# Altium schematic sheet WiFi_Portable_Sensor_Board_C0_MCU-PWR-UI_20180525.SchDoc (continued)

## Library data of the parts
C1 = CAP1338: Capacitor; Manufacturer 1: Murata Electronics North America; Manufacturer Part Number 1: GRM155R6YA105KE11D; Description_: CAP CER 1uF 35V 10% X5R SMD 0402; Dev Tools Status: Design; Owner: Sam Charles; Populated: YES; Temperature Coeffcient: X5R; Tolerance: 10%; Type: Ceramic; Category: Capacitor; Component Kind: Standard; ComponentLink1Description: SVN Datasheet; ComponentLink1URL: https://chn-vm-apps.microchip.com/mchprepo/parts/Datasheets/c02e.pdf; ComponentLink2Description: Local Datasheet; ComponentLink3Description: Open Symbol; ComponentLink4Description: Open Footprint; ComponentLink5Description: Open Footprint 2; ComponentLink6Description: Open Footprint 3; ComponentLink7Description: Open Footprint 4; Database Table Name: Capacitor; Library Name: MCHP-CDB.DbLib; MCHP-ID: CAP1338; Operating Temperature: -55C to +85C; Package: 0402; Quantity Override: 1; Revision: A; Status: MCL Design; Supplier 1: Digi-Key; Supplier Part Number 1: 490-10019-1-ND; Symbol: Capacitor NP; ComponentLink2URL: C:\ALTIUM_WORK\00001_MCHP-CDB\Datasheets\c02e.pdf; ComponentLink3URL: C:\ALTIUM_WORK\00001_MCHP-CDB\Library\LibraryRefs\Capacitor NP.SchLib; ComponentLink4URL: C:\ALTIUM_WORK\00001_MCHP-CDB\Library\Footprints\CAP_C_0402.PcbLib
C2 = CAP1461: Capacitor; Manufacturer 1: Murata Electronics North America; Manufacturer Part Number 1: GRM188R61E106MA73D; Description_: CAP CER 10uF 25V 20% X5R SMD 0603; Dev Tools Status: Production; Owner: Tim Moffat; Populated: YES; Temperature Coeffcient: X5R; Tolerance: 20%; Type: Ceramic; Category: Capacitor; Component Kind: Standard; ComponentLink1Description: SVN Datasheet; ComponentLink1URL: https://chn-vm-apps.microchip.com/mchprepo/parts/Datasheets/GRM188R61E106MA73-01.pdf; ComponentLink2Description: Local Datasheet; ComponentLink3Description: Open Symbol; ComponentLink4Description: Open Footprint; ComponentLink5Description: Open Footprint 2; ComponentLink6Description: Open Footprint 3; ComponentLink7Description: Open Footprint 4; Database Table Name: Capacitor; Library Name: MCHP-CDB.DbLib; MCHP-ID: CAP1461; Operating Temperature: -55C to 85C; Quantity Override: 1; Revision: 1; Status: MCL Design; Supplier 1: Digi-Key; Supplier Part Number 1: 490-7202-1-ND; Symbol: Capacitor NP; ComponentLink2URL: C:\ALTIUM_WORK\00001_MCHP-CDB\Datasheets\GRM188R61E106MA73-01.pdf; ComponentLink3URL: C:\ALTIUM_WORK\00001_MCHP-CDB\Library\LibraryRefs\Capacitor NP.SchLib; ComponentLink4URL: C:\ALTIUM_WORK\00001_MCHP-CDB\Library\Footprints\CAP_C_0603.PcbLib
C3 = CAP0638: Capacitor; Manufacturer 1: Taiyo Yuden; Manufacturer Part Number 1: LMK107BJ105KA-T; Description_: CAP CER 1uF 50V 10% X5R SMD 0603; Dev Tools Status: Production; Populated: Yes; Temperature Coeffcient: X5R; Tolerance: 10%; Type: Ceramic; Category: Capacitor; Component Kind: Standard; ComponentLink1Description: SVN Datasheet; ComponentLink1URL: https://chn-vm-apps.microchip.com/mchprepo/parts/Datasheets/mlcc01_e.pdf; ComponentLink2Description: Local Datasheet; ComponentLink3Description: Open Symbol; ComponentLink4Description: Open Footprint; ComponentLink5Description: Open Footprint 2; ComponentLink6Description: Open Footprint 3; ComponentLink7Description: Open Footprint 4; Database Table Name: Capacitor; Library Name: MCHP-CDB.DbLib; MCHP-ID: CAP0638; Operating Temperature: -55C to +85C; Quantity Override: 1; Revision: 1; Status: MCL Design; Supplier 1: Digi-Key; Supplier Part Number 1: 587-2400-1-ND; Symbol: Capacitor NP; ComponentLink2URL: C:\ALTIUM_WORK\00001_MCHP-CDB\Datasheets\mlcc01_e.pdf; ComponentLink3URL: C:\ALTIUM_WORK\00001_MCHP-CDB\Library\LibraryRefs\Capacitor NP.SchLib; ComponentLink4URL: C:\ALTIUM_WORK\00001_MCHP-CDB\Library\Footprints\CAP_C_0603.PcbLib
C4 C8-C10 C12 C31 = CAP0279: Capacitor; Manufacturer 1: KEMET; Manufacturer Part Number 1: C0402C104K8PACTU; Description_: CAP CER 0.1uF 10V 10% X5R SMD 0402; Dev Tools Status: Production; Populated: Yes; Temperature Coeffcient: X5R; Tolerance: 10%; Type: Ceramic; Category: Capacitor; Component Kind: Standard; ComponentLink1Description: SVN Datasheet; ComponentLink1URL: https://chn-vm-apps.microchip.com/mchprepo/parts/Datasheets/KEM_C1002_X7R_SMD.pdf; ComponentLink2Description: Local Datasheet; ComponentLink3Description: Open Symbol; ComponentLink4Description: Open Footprint; ComponentLink5Description: Open Footprint 2; ComponentLink6Description: Open Footprint 3; ComponentLink7Description: Open Footprint 4; Database Table Name: Capacitor; Library Name: MCHP-CDB.DbLib; Manufacturer 2: Murata; Manufacturer 3: KEMET; Manufacturer Part Number 2: GRM155R61A104KA01D; Manufacturer Part Number 3: C0402C104K8PAC; MCHP-ID: CAP0279; Operating Temperature: -55C to +85C; Quantity Override: 1; Revision: 1; Status: MCL Design; Supplier 1: Digi-Key; Supplier 2: Digi-Key; Supplier 3: KEMET; Supplier Part Number 1: 399-3027-1-ND; Supplier Part Number 2: 490-1318-2-ND; Supplier Part Number 3: C0402C104K8PAC; Symbol: Capacitor NP; ComponentLink2URL: C:\ALTIUM_WORK\00001_MCHP-CDB\Datasheets\KEM_C1002_X7R_SMD.pdf; ComponentLink3URL: C:\ALTIUM_WORK\00001_MCHP-CDB\Library\LibraryRefs\Capacitor NP.SchLib; ComponentLink4URL: C:\ALTIUM_WORK\00001_MCHP-CDB\Library\Footprints\CAP_C_0402.PcbLib
C5 C13 = CAP0616: Capacitor; Manufacturer 1: Murata; Manufacturer Part Number 1: GRM155R60J105KE19D; Description_: CAP CER 1uF 6.3V 10% X5R SMD 0402; Dev Tools Status: Production; Populated: Yes; Temperature Coeffcient: X5R; Tolerance: 10%; Type: Ceramic; Category: Capacitor; Component Kind: Standard; ComponentLink1Description: SVN Datasheet; ComponentLink1URL: https://chn-vm-apps.microchip.com/mchprepo/parts/Datasheets/L0005E.pdf; ComponentLink2Description: Local Datasheet; ComponentLink3Description: Open Symbol; ComponentLink4Description: Open Footprint; ComponentLink5Description: Open Footprint 2; ComponentLink6Description: Open Footprint 3; ComponentLink7Description: Open Footprint 4; Database Table Name: Capacitor; Library Name: MCHP-CDB.DbLib; MCHP-ID: CAP0616; Operating Temperature: -55C to +85C; Quantity Override: 1; Revision: 1; Status: MCL Design; Supplier 1: Digi-Key; Supplier Part Number 1: 490-1320-1-ND; Symbol: Capacitor NP; ComponentLink2URL: C:\ALTIUM_WORK\00001_MCHP-CDB\Datasheets\L0005E.pdf; ComponentLink3URL: C:\ALTIUM_WORK\00001_MCHP-CDB\Library\LibraryRefs\Capacitor NP.SchLib; ComponentLink4URL: C:\ALTIUM_WORK\00001_MCHP-CDB\Library\Footprints\CAP_C_0402.PcbLib
C6 C7 C11 = CAP1207: Capacitor; Manufacturer 1: Murata; Manufacturer Part Number 1: GRM155R60J475ME47D; Description_: CAP CER 4.7uF 6.3V 20% X5R SMD 0402; Dev Tools Status: Production; Owner: Tad Ahlvers; Populated: YES; Temperature Coeffcient: X5R; Tolerance: 20%; Type: Ceramic; Category: Capacitor; Component Kind: Standard; ComponentLink1Description: SVN Datasheet; ComponentLink1URL: https://chn-vm-apps.microchip.com/mchprepo/parts/Datasheets/c02e.pdf; ComponentLink2Description: Local Datasheet; ComponentLink3Description: Open Symbol; ComponentLink4Description: Open Footprint; ComponentLink5Description: Open Footprint 2; ComponentLink6Description: Open Footprint 3; ComponentLink7Description: Open Footprint 4; Database Table Name: Capacitor; Library Name: MCHP-CDB.DbLib; Manufacturer 2: TDK Corporation; Manufacturer Part Number 2: C1005X5R0J475M050BC; MCHP-ID: CAP1207; Operating Temperature: -55C to +85C; Quantity Override: 1; Revision: 1; Status: MCL Design; Supplier 1: Digi-Key; Supplier 2: Digi-Key; Supplier Part Number 1: 490-5915-2-ND; Supplier Part Number 2: 445-7395-2-ND; Symbol: Capacitor NP; ComponentLink2URL: C:\ALTIUM_WORK\00001_MCHP-CDB\Datasheets\c02e.pdf; ComponentLink3URL: C:\ALTIUM_WORK\00001_MCHP-CDB\Library\LibraryRefs\Capacitor NP.SchLib; ComponentLink4URL: C:\ALTIUM_WORK\00001_MCHP-CDB\Library\Footprints\CAP_C_0402.PcbLib
C15 C16 = CAP1712: Capacitor; Manufacturer 1: Murata Electronics North America; Manufacturer Part Number 1: GRM1555C1H6R8BA01D; Description_: CAP CER 6.8pF 50V 0.1pF NP0 SMD 0402; Dev Tools Status: Design; Owner: Gabor Bihari; Populated: YES; Temperature Coeffcient: NP0; Tolerance: 0.1pF; Type: Ceramic; Category: Capacitor; Component Kind: Standard; ComponentLink1Description: SVN Datasheet; ComponentLink1URL: https://chn-vm-apps.microchip.com/mchprepo/parts/Datasheets/c02e.pdf; ComponentLink2Description: Local Datasheet; ComponentLink3Description: Open Symbol; ComponentLink4Description: Open Footprint; ComponentLink5Description: Open Footprint 2; ComponentLink6Description: Open Footprint 3; ComponentLink7Description: Open Footprint 4; Database Table Name: Capacitor; Library Name: MCHP-CDB.DbLib; MCHP-ID: CAP1712; Operating Temperature: -55°C to 125°C; Quantity Override: 1; Revision: A; Status: MCL Design; Supplier 1: Digi-Key; Supplier Part Number 1: 490-6252-1-ND; Symbol: Capacitor NP; ComponentLink2URL: C:\ALTIUM_WORK\00001_MCHP-CDB\Datasheets\c02e.pdf; ComponentLink3URL: C:\ALTIUM_WORK\00001_MCHP-CDB\Library\LibraryRefs\Capacitor NP.SchLib; ComponentLink4URL: C:\ALTIUM_WORK\00001_MCHP-CDB\Library\Footprints\CAP_C_0402.PcbLib
C30 = CAP0638: Capacitor; Manufacturer 1: Taiyo Yuden; Manufacturer Part Number 1: LMK107BJ105KA-T; Description_: CAP CER 1uF 50V 10% X5R SMD 0603; Dev Tools Status: Production; Populated: Yes; Temperature Coeffcient: X5R; Tolerance: 10%; Type: Ceramic; Voltage: 50V; Category: Capacitor; Component Kind: Standard; ComponentLink1Description: SVN Datasheet; ComponentLink1URL: https://chn-vm-apps.microchip.com/mchprepo/parts/Datasheets/mlcc01_e.pdf; ComponentLink2Description: Local Datasheet; ComponentLink3Description: Open Symbol; ComponentLink4Description: Open Footprint; ComponentLink5Description: Open Footprint 2; ComponentLink6Description: Open Footprint 3; ComponentLink7Description: Open Footprint 4; Database Table Name: Capacitor; Library Name: MCHP-CDB.DbLib; MCHP-ID: CAP0638; Operating Temperature: -55C to +85C; Package: 0603; Quantity Override: 1; Revision: 1; Status: MCL Design; Supplier 1: Digi-Key; Supplier Part Number 1: 587-2400-1-ND; Symbol: Capacitor NP; ComponentLink2URL: C:\ALTIUM_WORK\00001_MCHP-CDB\Datasheets\mlcc01_e.pdf; ComponentLink3URL: C:\ALTIUM_WORK\00001_MCHP-CDB\Library\LibraryRefs\Capacitor NP.SchLib; ComponentLink4URL: C:\ALTIUM_WORK\00001_MCHP-CDB\Library\Footprints\CAP_C_0603.PcbLib
C201 = CAP0279: Capacitor; Manufacturer 1: KEMET; Manufacturer Part Number 1: C0402C104K8PACTU; Description_: CAP CER 100nF 10V 10% X5R SMD 0402; Dev Tools Status: Production; Populated: Yes; Temperature Coeffcient: X5R; Tolerance: 10%; Type: Ceramic; Category: Capacitor; Component Kind: Standard; ComponentLink1Description: SVN Datasheet; ComponentLink1URL: https://chn-vm-apps.microchip.com/mchprepo/parts/Datasheets/KEM_C1002_X7R_SMD.pdf; ComponentLink2Description: Local Datasheet; ComponentLink3Description: Open Symbol; ComponentLink4Description: Open Footprint; ComponentLink5Description: Open Footprint 2; ComponentLink6Description: Open Footprint 3; ComponentLink7Description: Open Footprint 4; Database Table Name: Capacitor; Library Name: MCHP-CDB.DbLib; Manufacturer 2: Murata; Manufacturer 3: KEMET; Manufacturer Part Number 2: GRM155R61A104KA01D; Manufacturer Part Number 3: C0402C104K8PAC; MCHP-ID: CAP0279; Operating Temperature: -55C to +85C; Quantity Override: 1; Revision: 1; Status: MCL Design; Supplier 1: Digi-Key; Supplier 2: Digi-Key; Supplier 3: KEMET; Supplier Part Number 1: 399-3027-1-ND; Supplier Part Number 2: 490-1318-2-ND; Supplier Part Number 3: C0402C104K8PAC; Symbol: Capacitor NP; ComponentLink2URL: C:\ALTIUM_WORK\00001_MCHP-CDB\Datasheets\KEM_C1002_X7R_SMD.pdf; ComponentLink3URL: C:\ALTIUM_WORK\00001_MCHP-CDB\Library\LibraryRefs\Capacitor NP.SchLib; ComponentLink4URL: C:\ALTIUM_WORK\00001_MCHP-CDB\Library\Footprints\CAP_C_0402.PcbLib
C202 = CAP0616: Capacitor; Manufacturer 1: Murata; Manufacturer Part Number 1: GRM155R60J105KE19D; Description_: CAP CER 100nF 16V 10% X5R SMD 0402; Dev Tools Status: Production; Populated: Yes; Temperature Coeffcient: X5R; Tolerance: 10%; Type: Ceramic; Category: Capacitor; Component Kind: Standard; ComponentLink1Description: SVN Datasheet; ComponentLink1URL: https://chn-vm-apps.microchip.com/mchprepo/parts/Datasheets/L0005E.pdf; ComponentLink2Description: Local Datasheet; ComponentLink3Description: Open Symbol; ComponentLink4Description: Open Footprint; ComponentLink5Description: Open Footprint 2; ComponentLink6Description: Open Footprint 3; ComponentLink7Description: Open Footprint 4; Database Table Name: Capacitor; Library Name: MCHP-CDB.DbLib; MCHP-ID: CAP0616; Operating Temperature: -55C to +85C; Quantity Override: 1; Revision: 1; Status: MCL Design; Supplier 1: Digi-Key; Supplier Part Number 1: 490-1320-1-ND; Symbol: Capacitor NP; ComponentLink2URL: C:\ALTIUM_WORK\00001_MCHP-CDB\Datasheets\L0005E.pdf; ComponentLink3URL: C:\ALTIUM_WORK\00001_MCHP-CDB\Library\LibraryRefs\Capacitor NP.SchLib; ComponentLink4URL: C:\ALTIUM_WORK\00001_MCHP-CDB\Library\Footprints\CAP_C_0402.PcbLib
D1-D4 = DIODE1346: Description_: DIODE TVS BIDIR SPE0572 5V SMD SOD-723; Dev Tools Status: Design; Owner: Gabor Bihari; Populated: YES; Reverse Voltage: 5V; Category: Diode; Component Kind: Standard; ComponentLink1Description: SVN Datasheet; ComponentLink1URL: https://chn-vm-apps.microchip.com/mchprepo/parts/Datasheets/SPE0572.pdf; ComponentLink2Description: Local Datasheet; ComponentLink3Description: Open Symbol; ComponentLink4Description: Open Footprint; ComponentLink5Description: Open Footprint 2; ComponentLink6Description: Open Footprint 3; ComponentLink7Description: Open Footprint 4; Database Table Name: Diode; Library Name: MCHP-CDB.DbLib; MCHP-ID: DIODE1346; Operating Temperature: -55°C to 125°C; Package: SOD-723; Quantity Override: 1; Revision: A; Status: MCL Design; Symbol: Diode TVS Bidirectional; Type: TVS; ComponentLink2URL: C:\ALTIUM_WORK\00001_MCHP-CDB\Datasheets\SPE0572.pdf; ComponentLink3URL: C:\ALTIUM_WORK\00001_MCHP-CDB\Library\LibraryRefs\Diode TVS Bidirectional.SchLib; ComponentLink4URL: C:\ALTIUM_WORK\00001_MCHP-CDB\Library\Footprints\SOD-723.PcbLib
D5 = DIODE0096: DIO RECT 1N4148 855mV 300mA 75V SOD-323; Manufacturer 1: Diodes Incorporated; Manufacturer Part Number 1: 1N4148WS-7-F; Dev Tools Status: Production; Populated: Yes; Power: 200mW; Reverse Current: 50uA; Type: Rectifier Single; Category: Diode; Component Kind: Standard; ComponentLink1Description: SVN Datasheet; ComponentLink1URL: https://chn-vm-apps.microchip.com/mchprepo/parts/Datasheets/ds30097.pdf; ComponentLink2Description: Local Datasheet; ComponentLink3Description: Open Symbol; ComponentLink4Description: Open Footprint; ComponentLink5Description: Open Footprint 2; ComponentLink6Description: Open Footprint 3; ComponentLink7Description: Open Footprint 4; Database Table Name: Diode; Description_: DIO RECT 1N4148 855mV 300mA 75V SOD-323; Forward Current: 300mA; Library Name: MCHP-CDB.DbLib; Manufacturer 2: Diodes Incorporated; Manufacturer Part Number 2: 1N4148WS-7; MCHP-ID: DIODE0096; Operating Temperature: -65C to +150C; Package: SOD-323; Quantity Override: 1; Reverse Voltage: 75V; Revision: 1; Status: MCL Design; Supplier 1: Digi-Key; Supplier 2: Digi-Key; Supplier Part Number 1: 1N4148WS-FDICT-ND; Supplier Part Number 2: 1N4148WSDITR-ND; Symbol: Diode Single; ComponentLink2URL: C:\ALTIUM_WORK\00001_MCHP-CDB\Datasheets\ds30097.pdf; ComponentLink3URL: C:\ALTIUM_WORK\00001_MCHP-CDB\Library\LibraryRefs\Diode Single.SchLib; ComponentLink4URL: C:\ALTIUM_WORK\00001_MCHP-CDB\Library\Footprints\SOD-323.PcbLib
FB1 = IND1170: Manufacturer 1: TDK Corporation; Manufacturer Part Number 1: BLM18KG471SN1D; DCR: 150 mOhm Max; Description_: FERRITE CHIP 470 OHM 1A 0603; Dev Tools Status: Design; Owner: Jose Garcia; Populated: YES; Test Frequency: 100MHz; Type: Ferrite Bead; Category: Inductor; Component Kind: Standard; ComponentLink1Description: SVN Datasheet; ComponentLink1URL: https://chn-vm-apps.microchip.com/mchprepo/parts/Datasheets/beads_commercial_power_mpz_en; ComponentLink2Description: Local Datasheet; ComponentLink3Description: Open Symbol; ComponentLink4Description: Open Footprint; ComponentLink5Description: Open Footprint 2; ComponentLink6Description: Open Footprint 3; ComponentLink7Description: Open Footprint 4; Database Table Name: Inductor; Description: Inductor; Library Name: MCHP-CDB.DbLib; MCHP-ID: IND1170; Operating Temperature: –55 to +125; Quantity Override: 1; Revision: A; Status: MCL Design; Supplier 1: Digi-Key; Supplier Part Number 1: 445-5218-1-ND; Symbol: Inductor; ComponentLink2URL: C:\ALTIUM_WORK\00001_MCHP-CDB\Datasheets\beads_commercial_power_mpz_en; ComponentLink3URL: C:\ALTIUM_WORK\00001_MCHP-CDB\Library\LibraryRefs\Inductor.SchLib; ComponentLink4URL: C:\ALTIUM_WORK\00001_MCHP-CDB\Library\Footprints\IND_C_0603.PcbLib
FB2 FB3 = IND1170: Manufacturer 1: TDK Corporation; DCR: 150 mOhm Max; Description_: FERRITE CHIP 470 OHM 1A 0603; Dev Tools Status: Design; Owner: Jose Garcia; Populated: YES; Test Frequency: 100MHz; Type: Ferrite Bead; Category: Inductor; Component Kind: Standard; ComponentLink1Description: SVN Datasheet; ComponentLink1URL: https://chn-vm-apps.microchip.com/mchprepo/parts/Datasheets/beads_commercial_power_mpz_en; ComponentLink2Description: Local Datasheet; ComponentLink3Description: Open Symbol; ComponentLink4Description: Open Footprint; ComponentLink5Description: Open Footprint 2; ComponentLink6Description: Open Footprint 3; ComponentLink7Description: Open Footprint 4; Database Table Name: Inductor; Description: Inductor; Library Name: MCHP-CDB.DbLib; MCHP-ID: IND1170; Operating Temperature: –55 to +125; Quantity Override: 1; Revision: A; Status: MCL Design; Supplier 1: Digi-Key; Supplier Part Number 1: 445-5218-1-ND; Symbol: Inductor; ComponentLink2URL: C:\ALTIUM_WORK\00001_MCHP-CDB\Datasheets\beads_commercial_power_mpz_en; ComponentLink3URL: C:\ALTIUM_WORK\00001_MCHP-CDB\Library\LibraryRefs\Inductor.SchLib; ComponentLink4URL: C:\ALTIUM_WORK\00001_MCHP-CDB\Library\Footprints\IND_C_0603.PcbLib
J1 = CON1383: Connector USB Mini; Manufacturer 1: FCI; Manufacturer Part Number 1: 10118194-0001LF; Description_: CON USB2.0 MICRO-B FEMALE TH/SMD R/A; Dev Tools Status: Production; Owner: Jamus Griego; Populated: YES; Category: Connector; Component Kind: Standard; ComponentLink1Description: SVN Datasheet; ComponentLink1URL: https://chn-vm-apps.microchip.com/mchprepo/parts/Datasheets/10118194.pdf; ComponentLink2Description: Local Datasheet; ComponentLink3Description: Open Symbol; ComponentLink4Description: Open Footprint; ComponentLink5Description: Open Footprint 2; ComponentLink6Description: Open Footprint 3; ComponentLink7Description: Open Footprint 4; Database Table Name: Connector; Library Name: MCHP-CDB.DbLib; Manufacturer 2: YangMing; Manufacturer Part Number 2: YM-02-363-N05F; MCHP-ID: CON1383; Quantity Override: 1; Revision: 1; Status: MCL Design; Supplier 1: Digi-Key; Supplier 2: Raynen; Supplier Part Number 1: 609-4618-1-ND; Supplier Part Number 2: YangMing YM-02-363-N05F; Symbol: CON USB2.0 Mini_Micro; Type: USB; ComponentLink2URL: C:\ALTIUM_WORK\00001_MCHP-CDB\Datasheets\10118194.pdf; ComponentLink3URL: C:\ALTIUM_WORK\00001_MCHP-CDB\Library\LibraryRefs\CON USB2.0 Mini_Micro.SchLib; ComponentLink4URL: C:\ALTIUM_WORK\00001_MCHP-CDB\Library\Footprints\CON_USB_Micro-AB_SMD_TH_RA_FCI_10118194-0001LF.PcbLib
J2 J3 J7 = CON1108: Manufacturer 1: FCI; Manufacturer Part Number 1: 77311-118-02LF; Database Table Name: Connector; Description_: CON HDR-2.54 Male 1x2 Gold 5.84MH TH VERT; Dev Tools Status: Production; Owner: Bogdan P (M91398); Populated: Yes; Category: Connector; Component Kind: Standard; ComponentLink1Description: SVN Datasheet; ComponentLink1URL: https://chn-vm-apps.microchip.com/mchprepo/parts/Datasheets/77311.pdf; ComponentLink2Description: Local Datasheet; ComponentLink3Description: Open Symbol; ComponentLink4Description: Open Footprint; ComponentLink5Description: Open Footprint 2; ComponentLink6Description: Open Footprint 3; ComponentLink7Description: Open Footprint 4; Library Name: MCHP-CDB.DbLib; Manufacturer 2: FCI; Manufacturer 3: FCI; Manufacturer 4: Samtec; Manufacturer 5: Sullins; Manufacturer 6: SPC Technology; Manufacturer 7: Samtec; Manufacturer 8: Nextron; Manufacturer 9: Samtec; Manufacturer Part Number 2: 68001-202HLF; Manufacturer Part Number 3: 68000-202HLF; Manufacturer Part Number 4: TSW-102-07-G-S; Manufacturer Part Number 5: PBC02SAAN; Manufacturer Part Number 6: SPC20481; Manufacturer Part Number 7: TSW-102-07-F-S; Manufacturer Part Number 8: R-211-0211-0021-000; Manufacturer Part Number 9: TSW-102-07-S-S; MCHP-ID: CON1108; Quantity Override: 1; Revision: 1; Status: MCL Design; Supplier 1: Digi-Key; Supplier 2: Digi-Key; Supplier 3: Digi-Key; Supplier 4: Digi-Key; Supplier 5: Digi-Key; Supplier 6: Newark; Supplier 7: Newark; Supplier 8: Raynen; Supplier 9: Samtec; Supplier Part Number 1: 609-4434-ND; Supplier Part Number 2: 609-3469-ND; Supplier Part Number 3: 609-3502-ND; Supplier Part Number 4: SAM1029-02-ND; Supplier Part Number 5: S1011E-02-ND; Supplier Part Number 6: 93K5734; Supplier Part Number 7: 79P3146; Supplier Part Number 8: R-211-0211-0021-000; Supplier Part Number 9: TSW-102-07-S-S; Symbol: Header 1x2; Type: Header 2.54mm; ComponentLink2URL: C:\ALTIUM_WORK\00001_MCHP-CDB\Datasheets\77311.pdf; ComponentLink3URL: C:\ALTIUM_WORK\00001_MCHP-CDB\Library\LibraryRefs\Header 1x2.SchLib; ComponentLink4URL: C:\ALTIUM_WORK\00001_MCHP-CDB\Library\Footprints\HDR_M_2.54_1x2.PcbLib
J5 = CON1497: Manufacturer 1: Amphenol FCI; Manufacturer Part Number 1: 20021111-00010T4LF; Database Table Name: Connector; Description_: CON HDR-1.27 Male 2x5 Gold 3.05MH TH VERT; Dev Tools Status: Design; Owner: Tad Ahlvers; Populated: YES; Category: Connector; Component Kind: Standard; ComponentLink1Description: SVN Datasheet; ComponentLink1URL: https://chn-vm-apps.microchip.com/mchprepo/parts/Datasheets/20021111.pdf; ComponentLink2Description: Local Datasheet; ComponentLink3Description: Open Symbol; ComponentLink4Description: Open Footprint; ComponentLink5Description: Open Footprint 2; ComponentLink6Description: Open Footprint 3; ComponentLink7Description: Open Footprint 4; Library Name: MCHP-CDB.DbLib; MCHP-ID: CON1497; Quantity Override: 1; Revision: A; Status: MCL Design; Supplier 1: Digi-Key; Supplier Part Number 1: 609-3712-ND; Symbol: Header 2x5; Type: Header 1.27mm; ComponentLink2URL: C:\ALTIUM_WORK\00001_MCHP-CDB\Datasheets\20021111.pdf; ComponentLink3URL: C:\ALTIUM_WORK\00001_MCHP-CDB\Library\LibraryRefs\Header 2x5.SchLib; ComponentLink4URL: C:\ALTIUM_WORK\00001_MCHP-CDB\Library\Footprints\HDR_M_1.27_2x5_TH_V_FCI_20021111-00010T4LF.PcbLib
J6 = CON0465: Manufacturer 1: Samtec; Manufacturer Part Number 1: TSW-103-07-T-S; Database Table Name: Connector; Description_: CON HDR-2.54 Male 1x3 Tin 5.84MH TH VERT; Dev Tools Status: Production; Populated: Yes; Category: Connector; Component Kind: Standard; ComponentLink1Description: SVN Datasheet; ComponentLink1URL: https://chn-vm-apps.microchip.com/mchprepo/parts/Datasheets/TSW-XXX-XX-XXX-X-XX-XXX-MKT.pdf; ComponentLink2Description: Local Datasheet; ComponentLink3Description: Open Symbol; ComponentLink4Description: Open Footprint; ComponentLink5Description: Open Footprint 2; ComponentLink6Description: Open Footprint 3; ComponentLink7Description: Open Footprint 4; Library Name: MCHP-CDB-Offline.DbLib; Manufacturer 2: SHENZHEN JUNHAO ENGINEERING; Manufacturer Part Number 2: R-211-0311-0021-000; MCHP-ID: CON0465; Quantity Override: 1; Revision: 1; Status: MCL Design; Supplier 1: Digi-Key; Supplier 2: Raynen; Supplier Part Number 1: SAM1035-03-ND; Supplier Part Number 2: CONN00253B01; Symbol: Header 1x3; Type: Header 2.54mm; ComponentLink2URL: C:\ALTIUM_WORK\00001_MCHP-CDB\Datasheets\TSW-XXX-XX-XXX-X-XX-XXX-MKT.pdf; ComponentLink3URL: C:\ALTIUM_WORK\00001_MCHP-CDB\Library\LibraryRefs\Header 1x3.SchLib; ComponentLink4URL: C:\ALTIUM_WORK\00001_MCHP-CDB\Library\Footprints\HDR_M_2.54_1x3.PcbLib
J9 = CON0313: Manufacturer 1: Samtec; Manufacturer Part Number 1: TSW-104-08-L-D; Database Table Name: Connector; Description_: CON HDR-2.54 Male 2x4 Gold 5.84MH TH VERT; Dev Tools Status: Production; Populated: Yes; Category: Connector; Component Kind: Standard; ComponentLink1Description: SVN Datasheet; ComponentLink1URL: https://chn-vm-apps.microchip.com/mchprepo/parts/Datasheets/TSW-XXX-XX-XXX-X-XX-XXX-MKT.pdf; ComponentLink2Description: Local Datasheet; ComponentLink3Description: Open Symbol; ComponentLink4Description: Open Footprint; ComponentLink5Description: Open Footprint 2; ComponentLink6Description: Open Footprint 3; ComponentLink7Description: Open Footprint 4; Library Name: MCHP-CDB.DbLib; MCHP-ID: CON0313; Quantity Override: 1; Revision: 1; Status: MCL Design; Supplier 1: Digi-Key; Supplier Part Number 1: SAM1040-04-ND; Symbol: Header 2x4; Type: Header 2.54mm; ComponentLink2URL: C:\ALTIUM_WORK\00001_MCHP-CDB\Datasheets\TSW-XXX-XX-XXX-X-XX-XXX-MKT.pdf; ComponentLink3URL: C:\ALTIUM_WORK\00001_MCHP-CDB\Library\LibraryRefs\Header 2x4.SchLib; ComponentLink4URL: C:\ALTIUM_WORK\00001_MCHP-CDB\Library\Footprints\HDR_M_2.54_2x4.PcbLib
J10 = CON0153: Manufacturer 1: Samtec; Manufacturer Part Number 1: TSW-120-07-G-D; Database Table Name: Connector; Description_: CON HDR-2.54 Male 2x20 Gold 5.84MH TH VERT; Dev Tools Status: Production; Owner: Stephen Humberd; Populated: YES; Category: Connector; Component Kind: Standard; ComponentLink1Description: SVN Datasheet; ComponentLink1URL: https://chn-vm-apps.microchip.com/mchprepo/parts/Datasheets/TSW-XXX-XX-XXX-X-XX-XXX-MKT.pdf; ComponentLink2Description: Local Datasheet; ComponentLink3Description: Open Symbol; ComponentLink4Description: Open Footprint; ComponentLink5Description: Open Footprint 2; ComponentLink6Description: Open Footprint 3; ComponentLink7Description: Open Footprint 4; Library Name: MCHP-CDB.DbLib; Manufacturer 2: Samtec; Manufacturer Part Number 2: TSW-120-07-S-D; MCHP-ID: CON0153; Quantity Override: 1; Revision: 1; Status: MCL Design; Supplier 1: Samtec; Supplier 2: Samtec; Supplier Part Number 1: TSW-120-07-G-D; Supplier Part Number 2: TSW-120-07-S-D; Symbol: Header 2x20; Type: Header 2.54mm; ComponentLink2URL: C:\ALTIUM_WORK\00001_MCHP-CDB\Datasheets\TSW-XXX-XX-XXX-X-XX-XXX-MKT.pdf; ComponentLink3URL: C:\ALTIUM_WORK\00001_MCHP-CDB\Library\LibraryRefs\Header 2x20.SchLib; ComponentLink4URL: C:\ALTIUM_WORK\00001_MCHP-CDB\Library\Footprints\HDR_M_2.54_2x20.PcbLib
JP1 JP3 = HW1006: Manufacturer 1: 3M; Manufacturer Part Number 1: 969102-0000-DA; Description_: MECH HW JUMPER 2.54mm 1x2; Dev Tools Status: Production; Owner: Mike Ragsdale; Populated: MECH; Value: Shunt 2.54mm 1x2; Category: Hardware; Component Kind: Standard; ComponentLink1Description: SVN Datasheet; ComponentLink1URL: https://chn-vm-apps.microchip.com/mchprepo/parts/Datasheets/63429.pdf; ComponentLink2Description: Local Datasheet; ComponentLink3Description: Open Symbol; ComponentLink4Description: Open Footprint; ComponentLink5Description: Open Footprint 2; ComponentLink6Description: Open Footprint 3; ComponentLink7Description: Open Footprint 4; Database Table Name: Mechanical; Library Name: MCHP-CDB.DbLib; Manufacturer 2: FCI; Manufacturer 3: Harwin; Manufacturer 4: Sullins; Manufacturer 5: Tyco Electronics (TE Connectivity); Manufacturer 6: Tyco Electronics (TE Connectivity); Manufacturer 7: Samtec; Manufacturer 8: Sullins Connector Solutions; Manufacturer 9: Sullins Connector Solutions; Manufacturer Part Number 2: 63429-202LF; Manufacturer Part Number 3: M7567-05; Manufacturer Part Number 4: SSC02SYAN; Manufacturer Part Number 5: 382811-6; Manufacturer Part Number 6: 2-382811-1; Manufacturer Part Number 7: SNT-100-BK-G; Manufacturer Part Number 8: STC02SYAN; Manufacturer Part Number 9: SPC02SVGN-RC; MCHP-ID: HW1006; Quantity Override: 1; Revision: 1; Status: MCL Design; Supplier 1: Digi-Key; Supplier 2: Digi-Key; Supplier 3: Digi-Key; Supplier 4: Digi-Key; Supplier 5: Digi-Key; Supplier 6: Digi-Key; Supplier 7: Samtec; Supplier 8: Digi-Key; Supplier 9: Digi-Key; Supplier Part Number 1: 3M9580-ND; Supplier Part Number 2: 609-2997-ND; Supplier Part Number 3: M7567-05-ND; Supplier Part Number 4: S9002-ND; Supplier Part Number 5: A26227-ND; Supplier Part Number 6: A31697-ND; Supplier Part Number 7: SNT-100-BK-G; Supplier Part Number 8: S9000-ND; Supplier Part Number 9: S9338-ND; Symbol: Jumper Mechanical; Type: Jumper; ComponentLink2URL: C:\ALTIUM_WORK\00001_MCHP-CDB\Datasheets\63429.pdf; ComponentLink3URL: C:\ALTIUM_WORK\00001_MCHP-CDB\Library\LibraryRefs\Jumper Mechanical.SchLib; ComponentLink4URL: C:\ALTIUM_WORK\00001_MCHP-CDB\Library\Footprints\Jumper_Mechanical.PcbLib
JP4 = HW1006: Manufacturer 1: 3M; Manufacturer Part Number 1: 969102-0000-DA; Description_: MECH HW JUMPER 2.54mm 1x2; Dev Tools Status: Production; Owner: Mike Ragsdale; Populated: MECH; Category: Hardware; Component Kind: Standard; ComponentLink1Description: SVN Datasheet; ComponentLink1URL: https://chn-vm-apps.microchip.com/mchprepo/parts/Datasheets/63429.pdf; ComponentLink2Description: Local Datasheet; ComponentLink3Description: Open Symbol; ComponentLink4Description: Open Footprint; ComponentLink5Description: Open Footprint 2; ComponentLink6Description: Open Footprint 3; ComponentLink7Description: Open Footprint 4; Database Table Name: Mechanical; Library Name: MCHP-CDB.DbLib; Manufacturer 2: FCI; Manufacturer 3: Harwin; Manufacturer 4: Sullins; Manufacturer 5: Tyco Electronics (TE Connectivity); Manufacturer 6: Tyco Electronics (TE Connectivity); Manufacturer 7: Samtec; Manufacturer 8: Sullins Connector Solutions; Manufacturer 9: Sullins Connector Solutions; Manufacturer Part Number 2: 63429-202LF; Manufacturer Part Number 3: M7567-05; Manufacturer Part Number 4: SSC02SYAN; Manufacturer Part Number 5: 382811-6; Manufacturer Part Number 6: 2-382811-1; Manufacturer Part Number 7: SNT-100-BK-G; Manufacturer Part Number 8: STC02SYAN; Manufacturer Part Number 9: SPC02SVGN-RC; MCHP-ID: HW1006; Quantity Override: 1; Revision: 1; Status: MCL Design; Supplier 1: Digi-Key; Supplier 2: Digi-Key; Supplier 3: Digi-Key; Supplier 4: Digi-Key; Supplier 5: Digi-Key; Supplier 6: Digi-Key; Supplier 7: Samtec; Supplier 8: Digi-Key; Supplier 9: Digi-Key; Supplier Part Number 1: 3M9580-ND; Supplier Part Number 2: 609-2997-ND; Supplier Part Number 3: M7567-05-ND; Supplier Part Number 4: S9002-ND; Supplier Part Number 5: A26227-ND; Supplier Part Number 6: A31697-ND; Supplier Part Number 7: SNT-100-BK-G; Supplier Part Number 8: S9000-ND; Supplier Part Number 9: S9338-ND; Symbol: Jumper Mechanical; Type: Jumper; ComponentLink2URL: C:\ALTIUM_WORK\00001_MCHP-CDB\Datasheets\63429.pdf; ComponentLink3URL: C:\ALTIUM_WORK\00001_MCHP-CDB\Library\LibraryRefs\Jumper Mechanical.SchLib; ComponentLink4URL: C:\ALTIUM_WORK\00001_MCHP-CDB\Library\Footprints\Jumper_Mechanical.PcbLib
L1 = IND0071: Manufacturer 1: TDK; Manufacturer Part Number 1: FLF3215T-4R7M; DCR: 0.09R; Description_: INDUCTOR 4.7uH 1A 20% SMD 1210; Dev Tools Status: Production; Populated: Yes; Saturation Current: 1A; Test Frequency: 1MHz; Category: Inductor; Component Kind: Standard; ComponentLink1Description: SVN Datasheet; ComponentLink1URL: https://chn-vm-apps.microchip.com/mchprepo/parts/Datasheets/e531_flf3215.pdf; ComponentLink2Description: Local Datasheet; ComponentLink3Description: Open Symbol; ComponentLink4Description: Open Footprint; ComponentLink5Description: Open Footprint 2; ComponentLink6Description: Open Footprint 3; ComponentLink7Description: Open Footprint 4; Database Table Name: Inductor; Description: Inductor; Library Name: MCHP-CDB.DbLib; MCHP-ID: IND0071; Operating Temperature: -40C to +125C; Package: 1212; Quantity Override: 1; Revision: 1; Status: MCL Design; Supplier 1: Digi-Key; Supplier Part Number 1: 445-4846-2-ND; Symbol: Inductor; Type: Inductor; ComponentLink2URL: C:\ALTIUM_WORK\00001_MCHP-CDB\Datasheets\e531_flf3215.pdf; ComponentLink3URL: C:\ALTIUM_WORK\00001_MCHP-CDB\Library\LibraryRefs\Inductor.SchLib; ComponentLink4URL: C:\ALTIUM_WORK\00001_MCHP-CDB\Library\Footprints\IND_C_1210.PcbLib
LD1 LD2 = DIODE1095: Manufacturer 1: Avago Technologies; Database Table Name: Diode; Description_: DIO LED TRI RED, GREEN, BLUE 1.9V, 3.5V, 3.5V 20mA, 20mA, 20mA HSMF-C118; Dev Tools Status: Design; Forward Current: 20mA, 20mA, 20mA; Owner: Tad Ahlvers; Populated: YES; Type: LED Tricolor; Category: Diode; Component Kind: Standard; ComponentLink1Description: SVN Datasheet; ComponentLink1URL: https://chn-vm-apps.microchip.com/mchprepo/parts/Datasheets/AV02-0610EN-1.pdf; ComponentLink2Description: Local Datasheet; ComponentLink3Description: Open Symbol; ComponentLink4Description: Open Footprint; ComponentLink5Description: Open Footprint 2; ComponentLink6Description: Open Footprint 3; ComponentLink7Description: Open Footprint 4; Library Name: MCHP-CDB.DbLib; MCHP-ID: DIODE1095; Operating Temperature: -30C to +85C; Package: 1210; Quantity Override: 1; Revision: A; Status: MCL Design; Supplier 1: Digi-Key; Supplier Part Number 1: 516-1778-1-ND; Symbol: LED TRI CA; ComponentLink2URL: C:\ALTIUM_WORK\00001_MCHP-CDB\Datasheets\AV02-0610EN-1.pdf; ComponentLink3URL: C:\ALTIUM_WORK\00001_MCHP-CDB\Library\LibraryRefs\LED TRI CA.SchLib; ComponentLink4URL: C:\ALTIUM_WORK\00001_MCHP-CDB\Library\Footprints\LED_HSMF-C118_L3.2W2.7_RED_GREEN_BLUE.PcbLib
Q101 = TRA0094: Transistor Bipolar; Manufacturer 1: NXP Semiconductors; Manufacturer Part Number 1: BC847BW,135; Current: 100mA; Description_: TRANS BJT NPN BC847W 45V 100mA 200mW SOT-323-3; Dev Tools Status: Production; Populated: YES; Power: 200mW; Type: BJT; Voltage: 45V; Category: Transistor; Component Kind: Standard; ComponentLink1Description: SVN Datasheet; ComponentLink1URL: https://chn-vm-apps.microchip.com/mchprepo/parts/Datasheets/BC847_BC547_SER.pdf; ComponentLink2Description: Local Datasheet; ComponentLink3Description: Open Symbol; ComponentLink4Description: Open Footprint; ComponentLink5Description: Open Footprint 2; ComponentLink6Description: Open Footprint 3; ComponentLink7Description: Open Footprint 4; Database Table Name: Transistor; Library Name: MCHP-CDB.DbLib; MCHP-ID: TRA0094; Operating Temperature: -65C to +150C; Package: SOT-323-3; Quantity Override: 1; Revision: 2; Status: MCL Design; Supplier 1: Digi-Key; Supplier Part Number 1: BC847BW,135-ND; Symbol: Transistor NPN BEC; ComponentLink2URL: C:\ALTIUM_WORK\00001_MCHP-CDB\Datasheets\BC847_BC547_SER.pdf; ComponentLink3URL: C:\ALTIUM_WORK\00001_MCHP-CDB\Library\LibraryRefs\Transistor NPN BEC.SchLib; ComponentLink4URL: C:\ALTIUM_WORK\00001_MCHP-CDB\Library\Footprints\SOT-323-3.PcbLib
R1 = RES1496: Manufacturer 1: Panasonic; Manufacturer Part Number 1: ERJ-2RKF2000X; Description_: RES TKF 200R 1% 1/10W SMD 0402; Dev Tools Status: Design; Populated: YES; Power: 1/10W; Temperature Coeffcient: 200ppm/C; Voltage: 50V; Category: Resistor; Component Kind: Standard; ComponentLink1Description: SVN Datasheet; ComponentLink1URL: https://chn-vm-apps.microchip.com/mchprepo/parts/Datasheets/AOA0000CE1.pdf; ComponentLink2Description: Local Datasheet; ComponentLink3Description: Open Symbol; ComponentLink4Description: Open Footprint; ComponentLink5Description: Open Footprint 2; ComponentLink6Description: Open Footprint 3; ComponentLink7Description: Open Footprint 4; Composition: TKF; Database Table Name: Resistor; Description: Resistor; Library Name: MCHP-CDB.DbLib; MCHP-ID: RES1496; Operating Temperature: -55C to +155C; Quantity Override: 1; Revision: 1; Status: MCL Design; Supplier 1: Digi-Key; Supplier Part Number 1: P200LTR-ND; Symbol: Resistor; Type: RES SMD; ComponentLink2URL: C:\ALTIUM_WORK\00001_MCHP-CDB\Datasheets\AOA0000CE1.pdf; ComponentLink3URL: C:\ALTIUM_WORK\00001_MCHP-CDB\Library\LibraryRefs\Resistor.SchLib; ComponentLink4URL: C:\ALTIUM_WORK\00001_MCHP-CDB\Library\Footprints\RES_C_0402.PcbLib
R2-R4 = RSMT0337: Manufacturer 1: Panasonic; Manufacturer Part Number 1: ERJ-2GEJ471X; Description_: RES TKF 470R 5% 1/16W SMD 0402; Dev Tools Status: Production; Populated: Yes; Power: 1/16W; Temperature Coeffcient: 200ppm/C; Voltage: 50V; Category: Resistor; Component Kind: Standard; ComponentLink1Description: SVN Datasheet; ComponentLink1URL: https://chn-vm-apps.microchip.com/mchprepo/parts/Datasheets/mcr_a.pdf; ComponentLink2Description: Local Datasheet; ComponentLink3Description: Open Symbol; ComponentLink4Description: Open Footprint; ComponentLink5Description: Open Footprint 2; ComponentLink6Description: Open Footprint 3; ComponentLink7Description: Open Footprint 4; Composition: TKF; Database Table Name: Resistor; Description: Resistor; Library Name: MCHP-CDB.DbLib; Manufacturer 2: ROHM; Manufacturer Part Number 2: MCR01MZPJ471; MCHP-ID: RSMT0337; Operating Temperature: -55C to +155C; Quantity Override: 1; Revision: 1; Status: MCL Design; Supplier 1: Digi-Key; Supplier 2: Digi-Key; Supplier Part Number 1: P470JCT-ND; Supplier Part Number 2: RHM470JCT-ND; Symbol: Resistor; Type: RES SMD; ComponentLink2URL: C:\ALTIUM_WORK\00001_MCHP-CDB\Datasheets\mcr_a.pdf; ComponentLink3URL: C:\ALTIUM_WORK\00001_MCHP-CDB\Library\LibraryRefs\Resistor.SchLib; ComponentLink4URL: C:\ALTIUM_WORK\00001_MCHP-CDB\Library\Footprints\RES_C_0402.PcbLib
R5 R35-R37 = RES1022: Manufacturer 1: Panasonic; Manufacturer Part Number 1: ERJ-2RKF1001X; Description_: RES TKF 1k 1% 1/10W SMD 0402; Dev Tools Status: Production; Owner: Tad Ahlvers; Populated: YES; Power: 1/10W; Temperature Coeffcient: 100ppm/C; Voltage: 50V; Category: Resistor; Component Kind: Standard; ComponentLink1Description: SVN Datasheet; ComponentLink1URL: https://chn-vm-apps.microchip.com/mchprepo/parts/Datasheets/AOA0000CE2.pdf; ComponentLink2Description: Local Datasheet; ComponentLink3Description: Open Symbol; ComponentLink4Description: Open Footprint; ComponentLink5Description: Open Footprint 2; ComponentLink6Description: Open Footprint 3; ComponentLink7Description: Open Footprint 4; Composition: TKF; Database Table Name: Resistor; Description: Resistor; Library Name: MCHP-CDB.DbLib; MCHP-ID: RES1022; Operating Temperature: -55C to +155C; Quantity Override: 1; Revision: 2; Status: MCL Design; Supplier 1: Digi-Key; Supplier Part Number 1: P1.00KLTR-ND; Symbol: Resistor; Type: RES SMD; ComponentLink2URL: C:\ALTIUM_WORK\00001_MCHP-CDB\Datasheets\AOA0000CE2.pdf; ComponentLink3URL: C:\ALTIUM_WORK\00001_MCHP-CDB\Library\LibraryRefs\Resistor.SchLib; ComponentLink4URL: C:\ALTIUM_WORK\00001_MCHP-CDB\Library\Footprints\RES_C_0402.PcbLib
R6 R7 = RSMT0742: Manufacturer 1: ROHM; Manufacturer Part Number 1: MCR01MZPF5602; Description_: RES TKF 56k 1% 1/16W SMD 0402; Dev Tools Status: Production; Populated: Yes; Power: 1/16W; Temperature Coeffcient: 100ppm/C; Voltage: 50V; Category: Resistor; Component Kind: Standard; ComponentLink1Description: SVN Datasheet; ComponentLink1URL: https://chn-vm-apps.microchip.com/mchprepo/parts/Datasheets/mcr_a.pdf; ComponentLink2Description: Local Datasheet; ComponentLink3Description: Open Symbol; ComponentLink4Description: Open Footprint; ComponentLink5Description: Open Footprint 2; ComponentLink6Description: Open Footprint 3; ComponentLink7Description: Open Footprint 4; Composition: TKF; Database Table Name: Resistor; Description: Resistor; Library Name: MCHP-CDB.DbLib; MCHP-ID: RSMT0742; Operating Temperature: -55C to +155C; Quantity Override: 1; Revision: 1; Status: MCL Design; Supplier 1: Digi-Key; Supplier Part Number 1: RHM56.0KLCT-ND; Symbol: Resistor; Type: RES SMD; ComponentLink2URL: C:\ALTIUM_WORK\00001_MCHP-CDB\Datasheets\mcr_a.pdf; ComponentLink3URL: C:\ALTIUM_WORK\00001_MCHP-CDB\Library\LibraryRefs\Resistor.SchLib; ComponentLink4URL: C:\ALTIUM_WORK\00001_MCHP-CDB\Library\Footprints\RES_C_0402.PcbLib
R8 R14-R19 = RSMT0316: Manufacturer 1: Panasonic; Manufacturer Part Number 1: ERJ-2GEJ102X; Description_: RES TKF 1k 5% 1/16W SMD 0402; Dev Tools Status: Production; Owner: Tad Ahlvers; Populated: YES; Power: 1/16W; Temperature Coeffcient: 200ppm/C; Voltage: 50V; Category: Resistor; Component Kind: Standard; ComponentLink1Description: SVN Datasheet; ComponentLink1URL: https://chn-vm-apps.microchip.com/mchprepo/parts/Datasheets/AOA0000CE1.pdf; ComponentLink2Description: Local Datasheet; ComponentLink3Description: Open Symbol; ComponentLink4Description: Open Footprint; ComponentLink5Description: Open Footprint 2; ComponentLink6Description: Open Footprint 3; ComponentLink7Description: Open Footprint 4; Composition: TKF; Database Table Name: Resistor; Description: Resistor; Library Name: MCHP-CDB-Offline.DbLib; MCHP-ID: RSMT0316; Operating Temperature: -55C to +155C; Quantity Override: 1; Revision: 1; Status: MCL Design; Supplier 1: Digi-Key; Supplier Part Number 1: P1.0KJCT-ND; Symbol: Resistor; Type: RES SMD; ComponentLink2URL: C:\ALTIUM_WORK\00001_MCHP-CDB\Datasheets\AOA0000CE1.pdf; ComponentLink3URL: C:\ALTIUM_WORK\00001_MCHP-CDB\Library\LibraryRefs\Resistor.SchLib; ComponentLink4URL: C:\ALTIUM_WORK\00001_MCHP-CDB\Library\Footprints\RES_C_0402.PcbLib
R9 R10 = RSMT0001: Manufacturer 1: Panasonic; Manufacturer Part Number 1: ERJ-3GSY0R00V; Description_: RES TKF 0R 1/10W SMD 0603; Dev Tools Status: Production; Populated: Yes; Power: 1/10W; Category: Resistor; Component Kind: Standard; ComponentLink1Description: SVN Datasheet; ComponentLink1URL: https://chn-vm-apps.microchip.com/mchprepo/parts/Datasheets/nrc.pdf; ComponentLink2Description: Local Datasheet; ComponentLink3Description: Open Symbol; ComponentLink4Description: Open Footprint; ComponentLink5Description: Open Footprint 2; ComponentLink6Description: Open Footprint 3; ComponentLink7Description: Open Footprint 4; Composition: TKF; Database Table Name: Resistor; Description: Resistor; Library Name: MCHP-CDB.DbLib; Manufacturer 2: Panasonic; Manufacturer 3: ROHM; Manufacturer 4: Stackpole Electronics Inc; Manufacturer 5: Stackpole Electronics Inc; Manufacturer 6: Vishay; Manufacturer 7: Yageo; Manufacturer 8: KOA Speer; Manufacturer 9: NIC Components; Manufacturer Part Number 2: ERJ-3GEY0R00V; Manufacturer Part Number 3: MCR03EZPJ000; Manufacturer Part Number 4: RMCF0603ZT0R00; Manufacturer Part Number 5: RMCF 1/16 0 R; Manufacturer Part Number 6: CRCW06030000Z0EA; Manufacturer Part Number 7: RC0603JR-070RL; Manufacturer Part Number 8: RK73Z1JTTP; Manufacturer Part Number 9: NRC06Z0TRF; MCHP-ID: RSMT0001; Operating Temperature: -55C to +155C; Quantity Override: 1; Revision: 2; Status: MCL Design; Supplier 1: Digi-Key; Supplier 2: Digi-Key; Supplier 3: Digi-Key; Supplier 4: Digi-Key; Supplier 5: Digi-Key; Supplier 6: Digi-Key; Supplier 7: Digi-Key; Supplier 8: KOA Speer; Supplier 9: NIC Components; Supplier Part Number 1: P0.0GTR-ND; Supplier Part Number 2: RHM0.0GCT-ND; Supplier Part Number 3: RMCF0603ZT0R00TR-ND; Supplier Part Number 4: RMCF0603ZT0R00CT-ND; Supplier Part Number 5: RMCF1/160RTR-ND; Supplier Part Number 6: 541-0.0GCT-ND; Supplier Part Number 7: 311-0.0GRCT-ND; Supplier Part Number 8: RK73Z1JTTP; Supplier Part Number 9: NRC06Z0TRF; Symbol: Resistor; Type: RES SMD; ComponentLink2URL: C:\ALTIUM_WORK\00001_MCHP-CDB\Datasheets\nrc.pdf; ComponentLink3URL: C:\ALTIUM_WORK\00001_MCHP-CDB\Library\LibraryRefs\Resistor.SchLib; ComponentLink4URL: C:\ALTIUM_WORK\00001_MCHP-CDB\Library\Footprints\RES_C_0603.PcbLib
R11-R13 = RES1008: Manufacturer 1: Panasonic - ECG; Manufacturer Part Number 1: ERJ-2GEJ221X; Description_: RES TKF 220R 5% 1/10W SMD 0402; Dev Tools Status: Production; Owner: Tad Ahlvers; Populated: YES; Power: 1/10W; Temperature Coeffcient: 200ppm/C; Voltage: 50V; Category: Resistor; Component Kind: Standard; ComponentLink1Description: SVN Datasheet; ComponentLink1URL: https://chn-vm-apps.microchip.com/mchprepo/parts/Datasheets/AOA0000CE1.pdf; ComponentLink2Description: Local Datasheet; ComponentLink3Description: Open Symbol; ComponentLink4Description: Open Footprint; ComponentLink5Description: Open Footprint 2; ComponentLink6Description: Open Footprint 3; ComponentLink7Description: Open Footprint 4; Composition: TKF; Database Table Name: Resistor; Description: Resistor; Library Name: MCHP-CDB.DbLib; MCHP-ID: RES1008; Operating Temperature: -55C to +155C; Quantity Override: 1; Revision: 0; Status: MCL Design; Supplier 1: Digi-Key; Supplier Part Number 1: P220JTR-ND; Symbol: Resistor; Type: RES SMD; ComponentLink2URL: C:\ALTIUM_WORK\00001_MCHP-CDB\Datasheets\AOA0000CE1.pdf; ComponentLink3URL: C:\ALTIUM_WORK\00001_MCHP-CDB\Library\LibraryRefs\Resistor.SchLib; ComponentLink4URL: C:\ALTIUM_WORK\00001_MCHP-CDB\Library\Footprints\RES_C_0402.PcbLib
R20-R22 = RSMT0735: Manufacturer 1: Panasonic; Manufacturer Part Number 1: ERJ-2GEJ103X; Description_: RES TKF 10k 5% 1/10W SMD 0402; Dev Tools Status: Production; Populated: Yes; Power: 1/10W; Temperature Coeffcient: 200ppm/C; Voltage: 50V; Category: Resistor; Component Kind: Standard; ComponentLink1Description: SVN Datasheet; ComponentLink1URL: https://chn-vm-apps.microchip.com/mchprepo/parts/Datasheets/AOA0000CE1.pdf; ComponentLink2Description: Local Datasheet; ComponentLink3Description: Open Symbol; ComponentLink4Description: Open Footprint; ComponentLink5Description: Open Footprint 2; ComponentLink6Description: Open Footprint 3; ComponentLink7Description: Open Footprint 4; Composition: TKF; Database Table Name: Resistor; Description: Resistor; Library Name: MCHP-CDB-Offline.DbLib; MCHP-ID: RSMT0735; Operating Temperature: -55C to +155C; Quantity Override: 1; Revision: 1; Status: MCL Design; Supplier 1: Digi-Key; Supplier Part Number 1: P10KJCT-ND; Symbol: Resistor; Type: RES SMD; ComponentLink2URL: C:\ALTIUM_WORK\00001_MCHP-CDB\Datasheets\AOA0000CE1.pdf; ComponentLink3URL: C:\ALTIUM_WORK\00001_MCHP-CDB\Library\LibraryRefs\Resistor.SchLib; ComponentLink4URL: C:\ALTIUM_WORK\00001_MCHP-CDB\Library\Footprints\RES_C_0402.PcbLib
R33 R34 R38-R41 = RSMT0730: Manufacturer 1: Panasonic; Manufacturer Part Number 1: ERJ-2GE0R00X; Description_: RES TKF 0R SMD 0402; Dev Tools Status: Production; Power: 1/10W; Category: Resistor; Component Kind: Standard; ComponentLink1Description: SVN Datasheet; ComponentLink1URL: https://chn-vm-apps.microchip.com/mchprepo/parts/Datasheets/AOA0000CE1.pdf; ComponentLink2Description: Local Datasheet; ComponentLink3Description: Open Symbol; ComponentLink4Description: Open Footprint; ComponentLink5Description: Open Footprint 2; ComponentLink6Description: Open Footprint 3; ComponentLink7Description: Open Footprint 4; Composition: TKF; Database Table Name: Resistor; Description: Resistor; Library Name: MCHP-CDB.DbLib; Manufacturer 2: Vishay; Manufacturer Part Number 2: CRCW04020000Z0ED; MCHP-ID: RSMT0730; Operating Temperature: -55C to +155C; Quantity Override: 1; Revision: 2; Status: MCL Design; Supplier 1: Digi-Key; Supplier 2: Digi-Key; Supplier Part Number 1: P0.0JCT-ND; Supplier Part Number 2: 541-0.0JTR-ND; Symbol: Resistor; Type: RES SMD; ComponentLink2URL: C:\ALTIUM_WORK\00001_MCHP-CDB\Datasheets\AOA0000CE1.pdf; ComponentLink3URL: C:\ALTIUM_WORK\00001_MCHP-CDB\Library\LibraryRefs\Resistor.SchLib; ComponentLink4URL: C:\ALTIUM_WORK\00001_MCHP-CDB\Library\Footprints\RES_C_0402.PcbLib
  R40: Package: 0402
R42 R43 = RSMT0730: Manufacturer 1: Panasonic; Manufacturer Part Number 1: ERJ-2GE0R00X; Description_: RES TKF 0R SMD 0402; Dev Tools Status: Production; Populated: 5%; Power: 1/10W; Category: Resistor; Component Kind: Standard; ComponentLink1Description: SVN Datasheet; ComponentLink1URL: https://chn-vm-apps.microchip.com/mchprepo/parts/Datasheets/AOA0000CE1.pdf; ComponentLink2Description: Local Datasheet; ComponentLink3Description: Open Symbol; ComponentLink4Description: Open Footprint; ComponentLink5Description: Open Footprint 2; ComponentLink6Description: Open Footprint 3; ComponentLink7Description: Open Footprint 4; Composition: TKF; Database Table Name: Resistor; Description: Resistor; Library Name: MCHP-CDB.DbLib; Manufacturer 2: Vishay; Manufacturer Part Number 2: CRCW04020000Z0ED; MCHP-ID: RSMT0730; Operating Temperature: -55C to +155C; Quantity Override: 1; Revision: 2; Status: MCL Design; Supplier 1: Digi-Key; Supplier 2: Digi-Key; Supplier Part Number 1: P0.0JCT-ND; Supplier Part Number 2: 541-0.0JTR-ND; Symbol: Resistor; Type: RES SMD; ComponentLink2URL: C:\ALTIUM_WORK\00001_MCHP-CDB\Datasheets\AOA0000CE1.pdf; ComponentLink3URL: C:\ALTIUM_WORK\00001_MCHP-CDB\Library\LibraryRefs\Resistor.SchLib; ComponentLink4URL: C:\ALTIUM_WORK\00001_MCHP-CDB\Library\Footprints\RES_C_0402.PcbLib
R44 R45 = RSMT0730: Manufacturer 1: Panasonic; Manufacturer Part Number 1: ERJ-2GE0R00X; Description_: RES TKF 0R SMD 0402; Dev Tools Status: Production; Populated: Yes; Power: 1/10W; Category: Resistor; Component Kind: Standard; ComponentLink1Description: SVN Datasheet; ComponentLink1URL: https://chn-vm-apps.microchip.com/mchprepo/parts/Datasheets/AOA0000CE1.pdf; ComponentLink2Description: Local Datasheet; ComponentLink3Description: Open Symbol; ComponentLink4Description: Open Footprint; ComponentLink5Description: Open Footprint 2; ComponentLink6Description: Open Footprint 3; ComponentLink7Description: Open Footprint 4; Composition: TKF; Database Table Name: Resistor; Description: Resistor; Library Name: MCHP-CDB.DbLib; Manufacturer 2: Vishay; Manufacturer Part Number 2: CRCW04020000Z0ED; MCHP-ID: RSMT0730; Operating Temperature: -55C to +155C; Quantity Override: 1; Revision: 2; Status: MCL Design; Supplier 1: Digi-Key; Supplier 2: Digi-Key; Supplier Part Number 1: P0.0JCT-ND; Supplier Part Number 2: 541-0.0JTR-ND; Symbol: Resistor; Type: RES SMD; ComponentLink2URL: C:\ALTIUM_WORK\00001_MCHP-CDB\Datasheets\AOA0000CE1.pdf; ComponentLink3URL: C:\ALTIUM_WORK\00001_MCHP-CDB\Library\LibraryRefs\Resistor.SchLib; ComponentLink4URL: C:\ALTIUM_WORK\00001_MCHP-CDB\Library\Footprints\RES_C_0402.PcbLib
R301 = RSMT0742: Manufacturer 1: ROHM; Manufacturer Part Number 1: MCR01MZPF5602; Description_: RES TKF 330 1% 1/16W SMD 0402; Dev Tools Status: Production; Populated: Yes; Power: 1/16W; Temperature Coeffcient: 100ppm/C; Voltage: 50V; Category: Resistor; Component Kind: Standard; ComponentLink1Description: SVN Datasheet; ComponentLink1URL: https://chn-vm-apps.microchip.com/mchprepo/parts/Datasheets/mcr_a.pdf; ComponentLink2Description: Local Datasheet; ComponentLink3Description: Open Symbol; ComponentLink4Description: Open Footprint; ComponentLink5Description: Open Footprint 2; ComponentLink6Description: Open Footprint 3; ComponentLink7Description: Open Footprint 4; Composition: TKF; Database Table Name: Resistor; Description: Resistor; Library Name: MCHP-CDB.DbLib; MCHP-ID: RSMT0742; Operating Temperature: -55C to +155C; Quantity Override: 1; Revision: 1; Status: MCL Design; Supplier 1: Digi-Key; Supplier Part Number 1: RHM56.0KLCT-ND; Symbol: Resistor; Type: RES SMD; ComponentLink2URL: C:\ALTIUM_WORK\00001_MCHP-CDB\Datasheets\mcr_a.pdf; ComponentLink3URL: C:\ALTIUM_WORK\00001_MCHP-CDB\Library\LibraryRefs\Resistor.SchLib; ComponentLink4URL: C:\ALTIUM_WORK\00001_MCHP-CDB\Library\Footprints\RES_C_0402.PcbLib
R302 = RSMT0316: Manufacturer 1: Panasonic; Manufacturer Part Number 1: ERJ-2GEJ102X; Description_: RES TKF 10k 5% 1/16W SMD 0402; Dev Tools Status: Production; Owner: Tad Ahlvers; Populated: YES; Power: 1/16W; Temperature Coeffcient: 200ppm/C; Voltage: 50V; Category: Resistor; Component Kind: Standard; ComponentLink1Description: SVN Datasheet; ComponentLink1URL: https://chn-vm-apps.microchip.com/mchprepo/parts/Datasheets/AOA0000CE1.pdf; ComponentLink2Description: Local Datasheet; ComponentLink3Description: Open Symbol; ComponentLink4Description: Open Footprint; ComponentLink5Description: Open Footprint 2; ComponentLink6Description: Open Footprint 3; ComponentLink7Description: Open Footprint 4; Composition: TKF; Database Table Name: Resistor; Description: Resistor; Library Name: MCHP-CDB-Offline.DbLib; MCHP-ID: RSMT0316; Operating Temperature: -55C to +155C; Quantity Override: 1; Revision: 1; Status: MCL Design; Supplier 1: Digi-Key; Supplier Part Number 1: P1.0KJCT-ND; Symbol: Resistor; Type: RES SMD; ComponentLink2URL: C:\ALTIUM_WORK\00001_MCHP-CDB\Datasheets\AOA0000CE1.pdf; ComponentLink3URL: C:\ALTIUM_WORK\00001_MCHP-CDB\Library\LibraryRefs\Resistor.SchLib; ComponentLink4URL: C:\ALTIUM_WORK\00001_MCHP-CDB\Library\Footprints\RES_C_0402.PcbLib
SW1-SW3 SW5 = SWITCH0087: Switch; Manufacturer 1: C&K Components; Manufacturer Part Number 1: RS285G05A2URT; Current: 50mA; Description_: SWITCH TACTILE SPST 12V 50mA RS285G05A2URT SMD; Dev Tools Status: Production; Populated: YES; Voltage: 12V; Category: Switch; Component Kind: Standard; ComponentLink1Description: SVN Datasheet; ComponentLink1URL: https://chn-vm-apps.microchip.com/mchprepo/parts/Datasheets/PTS635_9aug12.pdf; ComponentLink2Description: Local Datasheet; ComponentLink3Description: Open Symbol; ComponentLink4Description: Open Footprint; ComponentLink5Description: Open Footprint 2; ComponentLink6Description: Open Footprint 3; ComponentLink7Description: Open Footprint 4; Database Table Name: Switch; Library Name: MCHP-CDB-Offline.DbLib; Manufacturer 2: ROKI ELECTRONICS CO., LTD.; Manufacturer Part Number 2: TS08M-AR-PF; MCHP-ID: SWITCH0087; Operating Temperature: -40C to +60C; Quantity Override: 1; Revision: 2; Status: MCL Design; Supplier 1: Digi-Key; Supplier 2: ROKI ELECTRONICS CO., LTD.; Supplier Part Number 1: RS285G05A2URT-ND; Supplier Part Number 2: TS08M-AR-PF; Symbol: Switch 2 Pin; Type: Tactile; ComponentLink2URL: C:\ALTIUM_WORK\00001_MCHP-CDB\Datasheets\PTS635_9aug12.pdf; ComponentLink3URL: C:\ALTIUM_WORK\00001_MCHP-CDB\Library\LibraryRefs\Switch 2 Pin.SchLib; ComponentLink4URL: C:\ALTIUM_WORK\00001_MCHP-CDB\Library\Footprints\SW_TACT_SMD_CK_PTS635SL25SMTR.PcbLib
SW4 = SWITCH1091: Manufacturer 1: Copal Electronics Inc.; Current: 300mA; Description_: SWITCH SLIDE SPDT 4V 300MA CUS-12TB SMD; Dev Tools Status: Design; Owner: Gabor Bihari; Populated: YES; Voltage: 4V; Category: Switch; Component Kind: Standard; ComponentLink1Description: SVN Datasheet; ComponentLink1URL: https://chn-vm-apps.microchip.com/mchprepo/parts/Datasheets/cus.pdf; ComponentLink2Description: Local Datasheet; ComponentLink3Description: Open Symbol; ComponentLink4Description: Open Footprint; ComponentLink5Description: Open Footprint 2; ComponentLink6Description: Open Footprint 3; ComponentLink7Description: Open Footprint 4; Database Table Name: Switch; Library Name: MCHP-CDB.DbLib; MCHP-ID: SWITCH1091; Operating Temperature: -40°C to 85°C; Quantity Override: 1; Revision: A; Status: MCL Design; Supplier 1: Digi-Key; Supplier Part Number 1: 563-1102-1-ND; Symbol: SWITCH SLIDE 3 Pin SPDT; Type: Slide; ComponentLink2URL: C:\ALTIUM_WORK\00001_MCHP-CDB\Datasheets\cus.pdf; ComponentLink3URL: C:\ALTIUM_WORK\00001_MCHP-CDB\Library\LibraryRefs\SWITCH SLIDE 3 Pin SPDT.SchLib; ComponentLink4URL: C:\ALTIUM_WORK\00001_MCHP-CDB\Library\Footprints\SW_SLIDE_SMD_SPDT_RA_CUS-12B.PcbLib
